# Altium schematic sheet WM8978.SchDoc(WM8978)
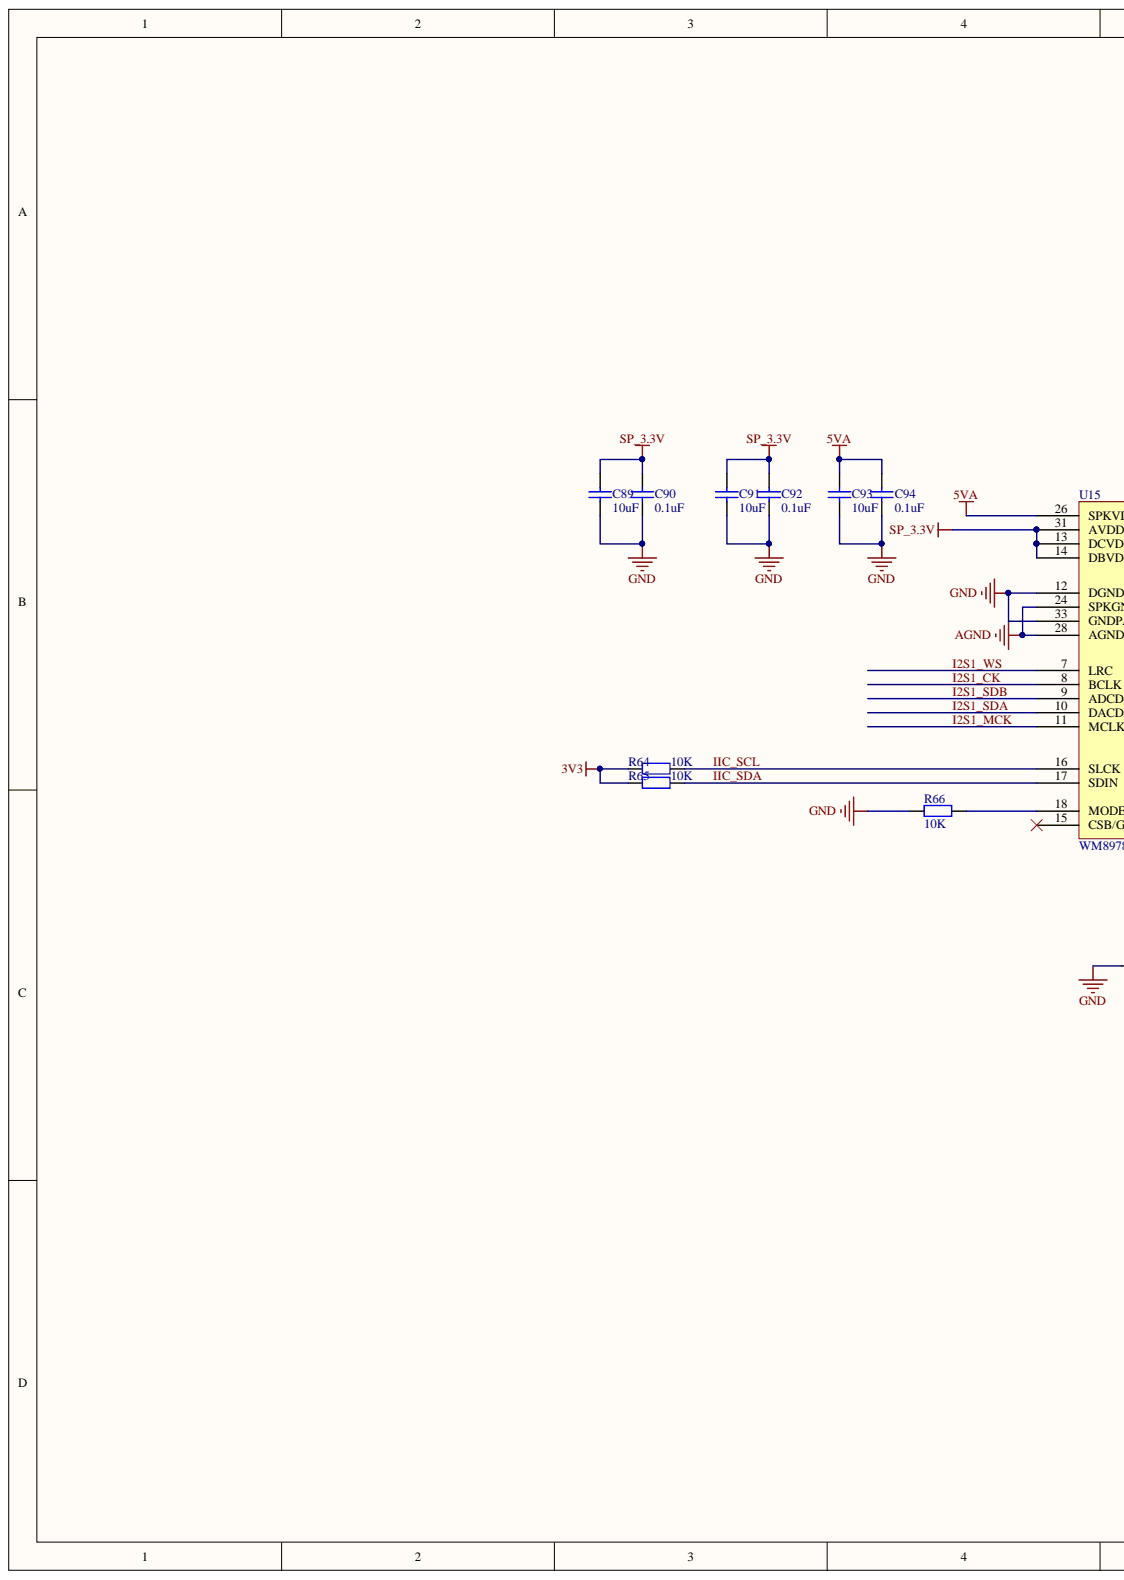
[diagram p0: WM8978.SchDoc, left]
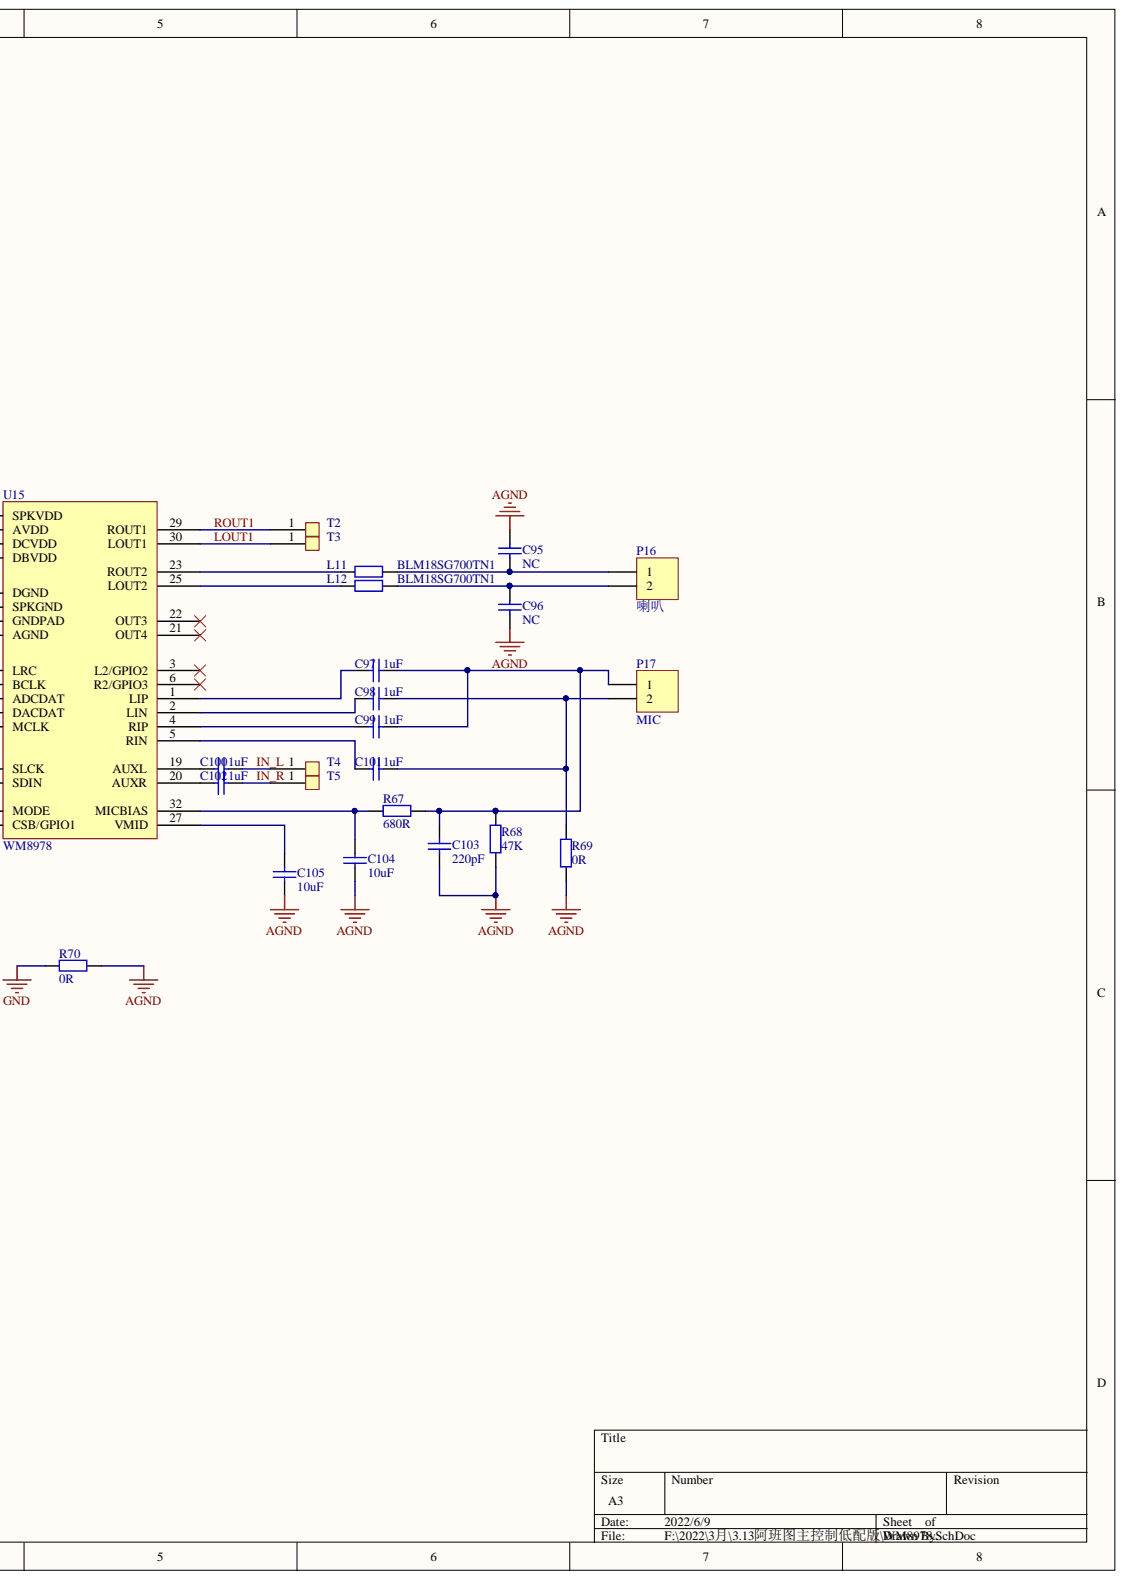
[diagram p1: WM8978.SchDoc, right]

## Parts
C89  10uF  [C0603]
C90  0.1uF  [C0603]
C91  10uF  [C0603]
C92  0.1uF  [C0603]
C93  10uF  [C0603]
C94  0.1uF  [C0603]
C95  NC  [C0603]
C96  NC  [C0603]
C97  1uF  [C0603]
C98  1uF  [C0603]
C99  1uF  [C0603]
C100  1uF  [C0603]  (value not drawn)
C101  1uF  [C0603]
C102  1uF  [C0603]  (value not drawn)
C103  220pF  [C0603]
C104  10uF  [C0603]
C105  10uF  [C0603]
L11  BLM18SG700TN1  [R0603]
L12  BLM18SG700TN1  [R0603]
P16  喇叭  [CON2_1.25]
P17  MIC  [MIC_6*2.2_L]
R64  10K  [R0603]
R65  10K  [R0603]
R66  10K  [R0603]
R67  680R  [R0603]
R68  47K  [R0603]
R69  0R  [R0603]
R70  0R  [R0603]
T2  (no value)  [PAD_1]
T3  (no value)  [PAD_1]
T4  (no value)  [PAD_1]
T5  (no value)  [PAD_1]
U15  WM8978  [QFN32_5*5]

## Nets
3V3: R64.2 R65.2
5VA: C93.1 C94.1 U15.26(SPKVDD)
AGND: C95.2 C96.2 C103.2 C104.2 C105.2 R68.2 R69.2 R70.1 U15.24(SPKGND) U15.28(AGND)
GND: C89.2 C90.2 C91.2 C92.2 C93.2 C94.2 R66.2 R70.2 U15.12(DGND) U15.33(GNDPAD)
SP_3.3V: C89.1 C90.1 C91.1 C92.1 U15.13(DCVDD) U15.14(DBVDD) U15.31(AVDD)
I2S1_CK: U15.8(BCLK)
I2S1_MCK: U15.11(MCLK)
I2S1_SDA: U15.10(DACDAT)
I2S1_SDB: U15.9(ADCDAT)
I2S1_WS: U15.7(LRC)
IIC_SCL: R64.1 U15.16(SLCK)
IIC_SDA: R65.1 U15.17(SDIN)
IN_L: C100.1 T4.1
IN_R: C102.1 T5.1
LOUT1: T3.1 U15.30(LOUT1)
ROUT1: T2.1 U15.29(ROUT1)
NetC95_1: C95.1 L11.1 P16.1
NetC96_1: C96.1 L12.1 P16.2
NetC97_1: C97.1 C99.1 C103.1 P17.1 R67.1 R68.1
NetC97_2: C97.2 U15.1(LIP)
NetC98_1: C98.1 C101.1 P17.2 R69.1
NetC98_2: C98.2 U15.2(LIN)
NetC99_2: C99.2 U15.4(RIP)
NetC100_2: C100.2 U15.19(AUXL)
NetC101_2: C101.2 U15.5(RIN)
NetC102_2: C102.2 U15.20(AUXR)
NetC104_1: C104.1 R67.2 U15.32(MICBIAS)
NetC105_1: C105.1 U15.27(VMID)
NetL11_2: L11.2 U15.23(ROUT2)
NetL12_2: L12.2 U15.25(LOUT2)
NetR66_1: R66.1 U15.18(MODE)

Not connected: U15 3(L2/GPIO2) 6(R2/GPIO3) 15(CSB/GPIO1) 21(OUT4) 22(OUT3)

## Library data of the parts
C89-C105 = Cap: Capacitor; Value: 100pF; Component Kind: Standard; LatestRevisionDate: 17-Jul-2002; LatestRevisionNote: Re-released for DXP Platform.; Library Name: Miscellaneous Devices.IntLib; PackageDescription: Radial Cap, Thru-Hole; 2 Leads; 0.3 in Pin Spacing; PackageReference: RAD-0.3; Published: 8-Jun-2000; Signal Integrity: Cap; Simulation: CAP; Publisher: Altium Limited
L11 L12 R64-R70 = Res2: Resistor; Value: 1K; Component Kind: Standard; LatestRevisionDate: 17-Jul-2002; LatestRevisionNote: Re-released for DXP Platform.; Library Name: Miscellaneous Devices.IntLib; PackageDescription: Axial Device, Thru-Hole; 2 Leads; 0.4 in Pin Spacing; PackageReference: AXIAL-0.4; Published: 8-Jun-2000; Signal Integrity: Res; Simulation: RESISTOR; Publisher: Altium Limited
P16 P17 = Header 2: Header, 2-Pin; Component Kind: Standard; LatestRevisionDate: 17-Jul-2002; LatestRevisionNote: Re-released for DXP Platform.; Library Name: Miscellaneous Connectors.IntLib; Signal Integrity: Connector; Publisher: Altium Limited
T2-T5 = TEST: Component Kind: Standard; Library Name: 芯片类.SchLib
U15 = WM8978: Component Kind: Standard; Library Name: 芯片类.SchLib
